# Altium schematic sheet motor_driver.SchDoc(motor_driver)
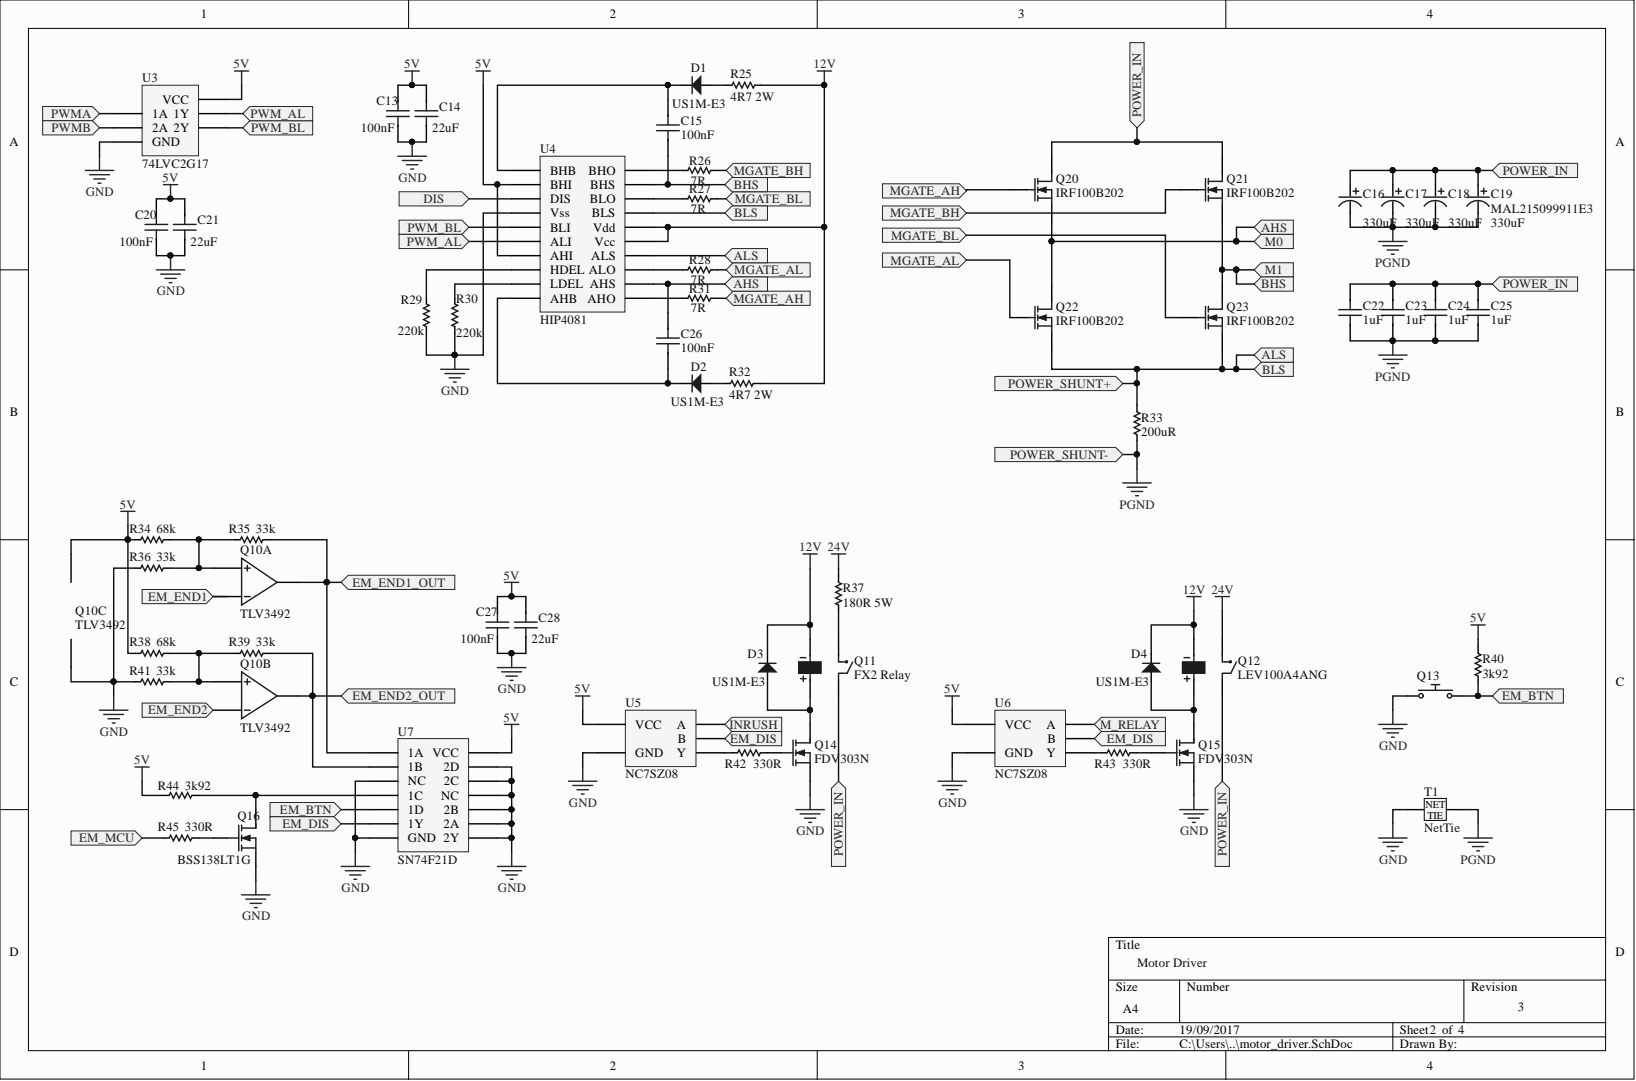
[diagram p0: motor_driver.SchDoc]

## Parts
C13  value 100nF  [CAP-0805]  (hidden comment: Capacitor)
C14  value 22uF  [CAP-0805]  (hidden comment: Capacitor)
C15  value 100nF  [CAP-0805]  (hidden comment: Capacitor)
C16  MAL215099911E3  value 330uF  [CAP-1621]
C17  MAL215099911E3  value 330uF  [CAP-1621]
C18  MAL215099911E3  value 330uF  [CAP-1621]
C19  MAL215099911E3  value 330uF  [CAP-1621]
C20  value 100nF  [CAP-0805]  (hidden comment: Capacitor)
C21  value 22uF  [CAP-0805]  (hidden comment: Capacitor)
C22  value 1uF  [CAP-0805]  (hidden comment: Capacitor)
C23  value 1uF  [CAP-0805]  (hidden comment: Capacitor)
C24  value 1uF  [CAP-0805]  (hidden comment: Capacitor)
C25  value 1uF  [CAP-0805]  (hidden comment: Capacitor)
C26  value 100nF  [CAP-0805]  (hidden comment: Capacitor)
C27  value 100nF  [CAP-0805]  (hidden comment: Capacitor)
C28  value 22uF  [CAP-0805]  (hidden comment: Capacitor)
D1  US1M-E3  [DO-214AC]
D2  US1M-E3  [DO-214AC]
D3  US1M-E3  [DO-214AC]
D4  US1M-E3  [DO-214AC]
Q10  TLV3492  [SOT23-8]  (parts A,B,C)
Q11  FX2 Relay  [G6BK]
Q12  LEV100A4ANG
Q13  Emergency Button  [2P-TERM]  (value not drawn)
Q14  FDV303N  [SOT-23B]
Q15  FDV303N  [SOT-23B]
Q16  BSS138LT1G  [SOT-23B]
Q20  IRF100B202  [TO-220AB]
Q21  IRF100B202  [TO-220AB]
Q22  IRF100B202  [TO-220AB]
Q23  IRF100B202  [TO-220AB]
R25  value 4R7 2W  [RES-2512]  (hidden comment: Resistor)
R26  value 7R  [RES-0805]  (hidden comment: Resistor)
R27  value 7R  [RES-0805]  (hidden comment: Resistor)
R28  value 7R  [RES-0805]  (hidden comment: Resistor)
R29  value 220k  [RES-0805]  (hidden comment: Resistor)
R30  value 220k  [RES-0805]  (hidden comment: Resistor)
R31  value 7R  [RES-0805]  (hidden comment: Resistor)
R32  value 4R7 2W  [RES-2512]  (hidden comment: Resistor)
R33  value 200uR  [RES-3920]  (hidden comment: Resistor)
R34  value 68k  [RES-0805]  (hidden comment: Resistor)
R35  value 33k  [RES-0805]  (hidden comment: Resistor)
R36  value 33k  [RES-0805]  (hidden comment: Resistor)
R37  value 180R 5W  [RES-RWS5]  (hidden comment: Resistor)
R38  value 68k  [RES-0805]  (hidden comment: Resistor)
R39  value 33k  [RES-0805]  (hidden comment: Resistor)
R40  value 3k92  [RES-0805]  (hidden comment: Resistor)
R41  value 33k  [RES-0805]  (hidden comment: Resistor)
R42  value 330R  [RES-0805]  (hidden comment: Resistor)
R43  value 330R  [RES-0805]  (hidden comment: Resistor)
R44  value 3k92  [RES-0805]  (hidden comment: Resistor)
R45  value 330R  [RES-0805]  (hidden comment: Resistor)
T1  NetTie  [NetTie]
U3  74LVC2G17  [SOT-363]
U4  HIP4081  [SOIC-20 WB]
U5  NC7SZ08  [SOT23-5]
U6  NC7SZ08  [SOT23-5]
U7  SN74F21D  [SOIC-14]

## Ports
AHS, ALS, BHS, BLS, DIS, EM_BTN, EM_DIS, EM_END1, EM_END1_OUT, EM_END2, EM_END2_OUT, EM_MCU, INRUSH, M0, M1, M_RELAY, MGATE_AH, MGATE_AL, MGATE_BH, MGATE_BL, POWER_IN, POWER_SHUNT+, POWER_SHUNT-, PWM_AL, PWM_BL, PWMA, PWMB

## Nets
5V: C13.2 C14.2 C20.2 C21.2 C27.2 C28.2 Q10.8 R34.1 R38.1 R40.0 R44.1 U3.5(VCC) U4.2(BHI) U4.7(AHI) U5.5(VCC) U6.5(VCC) U7.14(VCC)
12V: D3.1 D4.1 Q11.1 Q12.1 R25.1 R32.0 U4.15(Vcc) U4.16(Vdd)
24V: Q12.4 R37.0
GND: C13.1 C14.1 C20.1 C21.1 C27.1 C28.1 Q10.4 Q13.1 Q14.2 Q15.2 Q16.2 R29.0 R30.0 R36.1 R41.1 T1.2 U3.2(GND) U4.4(Vss) U5.3(GND) U6.3(GND) U7.3(NC) U7.7(GND) U7.8(2Y) U7.9(2A) U7.10(2B) U7.11(NC) U7.12(2C) U7.13(2D)
PGND: C16.2 C17.2 C18.2 C19.2 C22.1 C23.1 C24.1 C25.1 R33.1 T1.1
AHS: C26.2 Q20.3 Q22.2 U4.12(AHS) ; port AHS, port M0
ALS: Q22.3 Q23.3 R33.0 U4.14(ALS) U4.17(BLS) ; port ALS, port BLS
BHS: C15.1 Q21.3 Q23.2 U4.19(BHS) ; port BHS, port M1
DIS: U4.3(DIS) ; port DIS
EM_BTN: Q13.1 R40.1 U7.5(1D) ; port EM_BTN
EM_DIS: U5.2(B) U6.2(B) U7.6(1Y) ; port EM_DIS
EM_END1_OUT: Q10.1 R35.0 U7.1(1A) ; port EM_END1_OUT
EM_END2: Q10.6 ; port EM_END2
EM_END2_OUT: Q10.7 R39.0 U7.2(1B) ; port EM_END2_OUT
EM_MCU: R45.1 ; port EM_MCU
INRUSH: U5.1(A) ; port INRUSH
M_RELAY: U6.1(A) ; port M_RELAY
MGATE_AH: Q20.1 R31.0 ; port MGATE_AH
MGATE_AL: Q22.1 R28.0 ; port MGATE_AL
MGATE_BH: Q21.1 R26.0 ; port MGATE_BH
MGATE_BL: Q23.1 R27.0 ; port MGATE_BL
POWER_IN: C16.1 C17.1 C18.1 C19.1 C22.2 C23.2 C24.2 C25.2 Q11.3 Q12.3 Q20.2 Q21.2 ; port POWER_IN
PWM_AL: U3.6(1Y) U4.6(ALI) ; port PWM_AL
PWM_BL: U3.4(2Y) U4.5(BLI) ; port PWM_BL
PWMA: U3.1(1A) ; port PWMA
PWMB: U3.3(2A) ; port PWMB
NetC15_2: C15.2 D1.1 U4.1(BHB)
NetC26_1: C26.1 D2.1 U4.10(AHB)
NetD1_2: D1.2 R25.0
NetD2_2: D2.2 R32.1
NetD3_2: D3.2 Q11.8 Q14.3
NetD4_2: D4.2 Q12.8 Q15.3
NetQ10_5: Q10.5 R38.0 R39.1 R41.0
NetQ11_4: Q11.4 R37.1
NetQ14_1: Q14.1 R42.0
NetQ15_1: Q15.1 R43.0
NetQ16_1: Q16.1 R45.0
NetQ16_3: Q16.3 R44.0 U7.4(1C)
NetR26_1: R26.1 U4.20(BHO)
NetR27_1: R27.1 U4.18(BLO)
NetR28_1: R28.1 U4.13(ALO)
NetR29_1: R29.1 U4.8(HDEL)
NetR30_1: R30.1 U4.9(LDEL)
NetR31_1: R31.1 U4.11(AHO)
NetR34_0: R34.0 R35.1 R36.0
NetR42_1: R42.1 U5.4(Y)
NetR43_1: R43.1 U6.4(Y)
N00236: ; port EM_END1

Not connected: Q10 2 3

## Library data of the parts
C16-C19 = Electrolytic Capacitor: Component Kind: Standard; Library Name: SDUEmbedded.SchLib
D1-D4 = Diode: Component Kind: Standard; Library Name: SDUEmbedded.SchLib
Q16 = BSS138LT1G: N-Channel MOSFET; Published: 8-Jun-2000; PackageVersion: 1995/1996; PackageReference: E3; PackageDescription: TO, Flat Index; 3 In-Line, Axial Leads; Body Dia. 4.6mm; Lead Dia. 0.5 mm (max); LatestRevisionNote: Re-released for DXP Platform.; LatestRevisionDate: 17-Jul-2002; Component Kind: Standard; Publisher: Altium Limited; Library Name: SDUEmbedded.SchLib
U3 = 74LVC2G17: 74LVC2G17 Dual non-inverting Schmitt-trigger with 5v tolerant input; Component Kind: Standard; Library Name: SDUEmbedded.SchLib
U7 = SN74F21D: Dual 4-Input AND Gate; Component Kind: Standard; Library Name: SDUEmbedded.SchLib
C13-C15 C20-C28 = Capacitor: Description: Capacitor; Component Kind: Standard; Library Name: SDUEmbedded.SchLib
Q10 = TLV3492: Component Kind: Standard; Library Name: SDUEmbedded.SchLib
Q11 = FX2 Relay: Component Kind: Standard; Library Name: SDUEmbedded.SchLib
Q12 = LEV100A4ANG: Component Kind: Standard; Library Name: SDUEmbedded.SchLib
Q13 = Emergency Button: Component Kind: Standard; Library Name: SDUEmbedded.SchLib
Q14 Q15 = FDV303N: Component Kind: Standard; Library Name: SDUEmbedded.SchLib
Q20-Q23 = IRF100B202: Component Kind: Standard; Library Name: SDUEmbedded.SchLib
R25-R45 = Resistor: Description: Resistor; Component Kind: Standard; Library Name: SDUEmbedded.SchLib
T1 = NetTie: Component Kind: Net Tie; Library Name: SDUEmbedded.SchLib
U4 = HIP4081: Component Kind: Standard; Library Name: SDUEmbedded.SchLib
U5 U6 = NC7SZ08: Component Kind: Standard; Library Name: SDUEmbedded.SchLib
